# Altium schematic sheet 02_PS_ETH.SchDoc
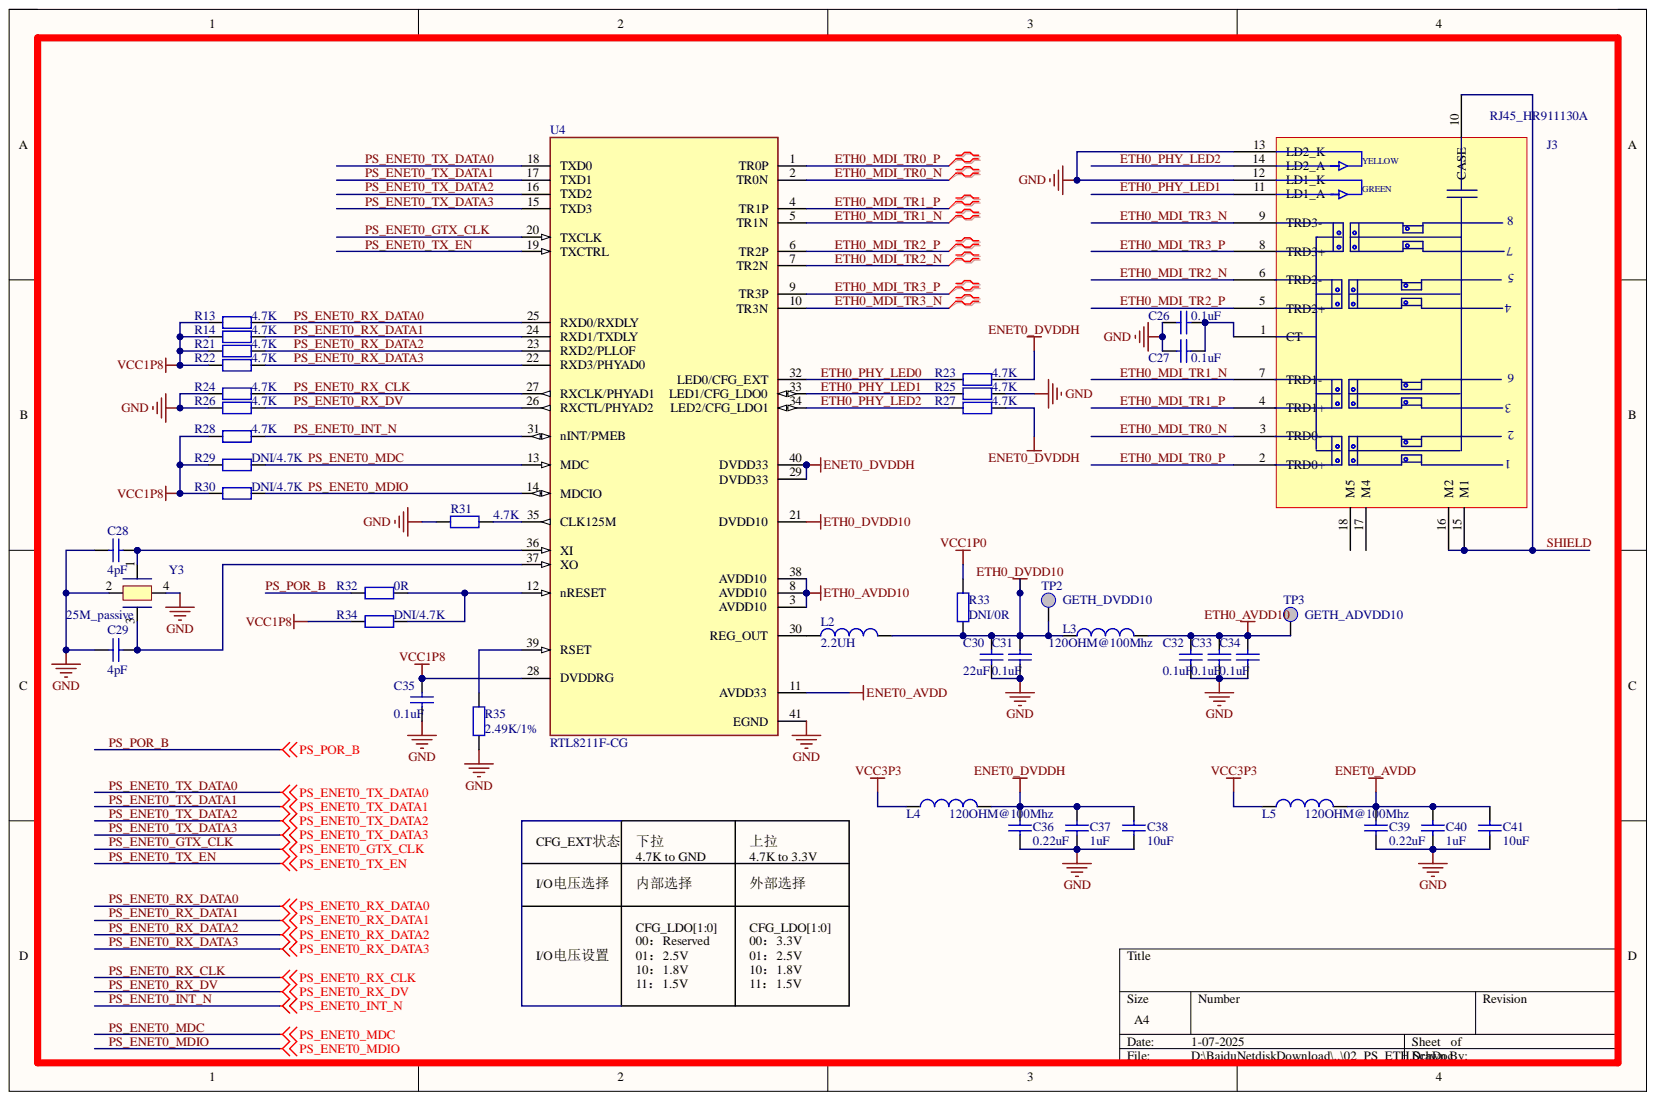
[diagram p0: 02_PS_ETH.SchDoc]

## Parts
C26  0.1uF  [C0603]
C27  0.1uF  [C0603]
C28  4pF  [C0603]
C29  4pF  [C0603]
C30  22uF  [C0805]
C31  0.1uF  [C0603]
C32  0.1uF  [C0603]
C33  0.1uF  [C0603]
C34  0.1uF  [C0603]
C35  0.1uF  [C0603]
C36  0.22uF  [C0603]
C37  1uF  [C0603]
C38  10uF  [C0805]
C39  0.22uF  [C0603]
C40  1uF  [C0603]
C41  10uF  [C0805]
J3  RJ45_HR911130A  [RJ45_HR911130C]
L2  2.2UH  [L0603]
L3  120OHM@100Mhz  [L0603]
L4  120OHM@100Mhz  [L0603]
L5  120OHM@100Mhz  [L0603]
R13  4.7K  [0603R]
R14  4.7K  [0603R]
R21  4.7K  [0603R]
R22  4.7K  [0603R]
R23  4.7K  [0603R]
R24  4.7K  [0603R]
R25  4.7K  [0603R]
R26  4.7K  [0603R]
R27  4.7K  [0603R]
R28  4.7K  [0603R]
R29  DNI/4.7K  [0603R]
R30  DNI/4.7K  [0603R]
R31  4.7K  [0603R]
R32  0R  [R0603]
R33  DNI/0R  [0603R]
R34  DNI/4.7K  [0603R]
R35  2.49K/1%  [0603R]
TP2  GETH_DVDD10  [tp32]
TP3  GETH_ADVDD10  [tp32]
U4  RTL8211F-CG  [qfn-40_l5_0-w5_0-p0_4-bl-ep]
Y3  25M_passive  [X4-3225]

## Nets
ENET0_AVDD: C39.2 C40.2 C41.2 L5.2 U4.11(AVDD33)
ENET0_DVDDH: C36.2 C37.2 C38.2 L4.2 R23.2 R27.2 U4.29(DVDD33) U4.40(DVDD33)
ETH0_AVDD10: C32.1 C33.1 C34.1 L3.2 TP3.1 U4.3(AVDD10) U4.8(AVDD10) U4.38(AVDD10)
ETH0_DVDD10: C30.1 C31.1 L2.2 L3.1 R33.2 TP2.1 U4.21(DVDD10)
GND: C26.1 C27.1 C28.1 C29.1 C30.2 C31.2 C32.2 C33.2 C34.2 C35.2 C36.1 C37.1 C38.1 C39.1 C40.1 C41.1 J3.12(LD1_K) J3.13(LD2_K) R24.1 R25.2 R26.1 R31.2 R35.1 U4.41(EGND) Y3.2 Y3.4
VCC1P0: R33.1
VCC1P8: C35.1 R13.1 R14.1 R21.1 R22.1 R28.1 R29.1 R30.1 R34.1 U4.28(DVDDRG)
VCC3P3: L4.1 L5.1
ETH0_MDI_TR0_N: J3.3(TRD0-) U4.2(TR0N)
ETH0_MDI_TR0_P: J3.2(TRD0+) U4.1(TR0P)
ETH0_MDI_TR1_N: J3.7(TRD1-) U4.5(TR1N)
ETH0_MDI_TR1_P: J3.4(TRD1+) U4.4(TR1P)
ETH0_MDI_TR2_N: J3.6(TRD2-) U4.7(TR2N)
ETH0_MDI_TR2_P: J3.5(TRD2+) U4.6(TR2P)
ETH0_MDI_TR3_N: J3.9(TRD3-) U4.10(TR3N)
ETH0_MDI_TR3_P: J3.8(TRD3+) U4.9(TR3P)
ETH0_PHY_LED0: R23.1 U4.32(LED0/CFG_EXT)
ETH0_PHY_LED1: J3.11(LD1_A) R25.1 U4.33(LED1/CFG_LDO0)
ETH0_PHY_LED2: J3.14(LD2_A) R27.1 U4.34(LED2/CFG_LDO1)
PS_ENET0_GTX_CLK: U4.20(TXCLK)
PS_ENET0_INT_N: R28.2 U4.31(nINT/PMEB)
PS_ENET0_MDC: R29.2 U4.13(MDC)
PS_ENET0_MDIO: R30.2 U4.14(MDCIO)
PS_ENET0_RX_CLK: R24.2 U4.27(RXCLK/PHYAD1)
PS_ENET0_RX_DATA0: R13.2 U4.25(RXD0/RXDLY)
PS_ENET0_RX_DATA1: R14.2 U4.24(RXD1/TXDLY)
PS_ENET0_RX_DATA2: R21.2 U4.23(RXD2/PLLOF)
PS_ENET0_RX_DATA3: R22.2 U4.22(RXD3/PHYAD0)
PS_ENET0_RX_DV: R26.2 U4.26(RXCTL/PHYAD2)
PS_ENET0_TX_DATA0: U4.18(TXD0)
PS_ENET0_TX_DATA1: U4.17(TXD1)
PS_ENET0_TX_DATA2: U4.16(TXD2)
PS_ENET0_TX_DATA3: U4.15(TXD3)
PS_ENET0_TX_EN: U4.19(TXCTRL)
PS_POR_B: R32.1
SHIELD: J3.10(CASE) J3.15(M1) J3.16(M2)
NetC26_2: C26.2 C27.2 J3.1(CT)
NetC28_2: C28.2 U4.36(XI) Y3.1
NetC29_2: C29.2 U4.37(XO) Y3.3
NetL2_1: L2.1 U4.30(REG_OUT)
NetR31_1: R31.1 U4.35(CLK125M)
NetR32_2: R32.2 R34.2 U4.12(nRESET)
NetR35_2: R35.2 U4.39(RSET)

Not connected: J3 17(M4) 18(M5)

## Library data of the parts
C26-C41 = Cap: Capacitor; Component Kind: Standard; LatestRevisionDate: 17-Jul-2002; LatestRevisionNote: Re-released for DXP Platform.; PackageReference: RAD-0.3; Published: 8-Jun-2000; Signal Integrity: Cap; Simulation: CAP; Publisher: Altium Limited
  C26 C27 C31-C35: Value: 0.1uF
  C28 C29: Library Name: Miscellaneous Devices.IntLib; PackageDescription: Radial Cap, Thru-Hole; 2 Leads; 0.3 in Pin Spacing; Value: 4pF
  C30: Value: 22uF
  C36 C39: Library Name: Miscellaneous Devices.IntLib; PackageDescription: Radial Cap, Thru-Hole; 2 Leads; 0.3 in Pin Spacing; Value: 0.22uF
  C37 C40: Library Name: Miscellaneous Devices.IntLib; PackageDescription: Radial Cap, Thru-Hole; 2 Leads; 0.3 in Pin Spacing; Value: 1uF
  C38 C41: Library Name: Miscellaneous Devices.IntLib; PackageDescription: Radial Cap, Thru-Hole; 2 Leads; 0.3 in Pin Spacing; Value: 10uF
  C26 C27 C30-C35: Library Name: 元件库封装.pcblib
J3 = GE_RJ45_2: Component Kind: Standard; Library Name: Schlib1.SchLib; Value: RJ45_HR911130A
L2 = Inductor: Code_IEC: 1005; Code_JEITA: 0402; Component Kind: Standard; Description: Inductor; LatestRevisionDate: 29-May-2009; LatestRevisionNote: IPC-7351 Footprint Added.; Library Name: Miscellaneous Devices.IntLib; PackageDescription: Chip Inductor; PackageReference: 0402-A; Published: 8-Jun-2000; Value: 2.2UH; Publisher: Altium Limited
L3-L5 = Inductor: Code_IEC: 1005; Value: 100ohm/1.2A; Code_JEITA: 0402; Component Kind: Standard; Description: Inductor; LatestRevisionDate: 29-May-2009; LatestRevisionNote: IPC-7351 Footprint Added.; Library Name: Miscellaneous Devices.IntLib; PackageDescription: Chip Inductor; PackageReference: 0402-A; Published: 8-Jun-2000; Publisher: Altium Limited
R13 R14 R21-R35 = Res2: Resistor; Component Kind: Standard; LatestRevisionDate: 17-Jul-2002; LatestRevisionNote: Re-released for DXP Platform.; PackageReference: AXIAL-0.4; Published: 8-Jun-2000; Library Name: 元件封装库.pcblib; Publisher: Altium Limited
  R13 R14 R21-R28 R31: Value: 4.7K
  R29 R30 R34: Value: DNI/4.7K
  R32: Value: 0R
  R33: Value: DNI/0R
  R35: Value: 2.49K/1%
TP2 TP3 = TestPoint: Component Kind: Standard; Library Name: USB302.Lib
U4 = RTL8211FDI: Component Kind: Standard; Library Name: gjws.SCHLIB
Y3 = OSC: Component Kind: Standard; Library Name: SCHLIB.SchLib
